# Altium schematic sheet 07_V5_LED.SchDoc(07_V5_LED)
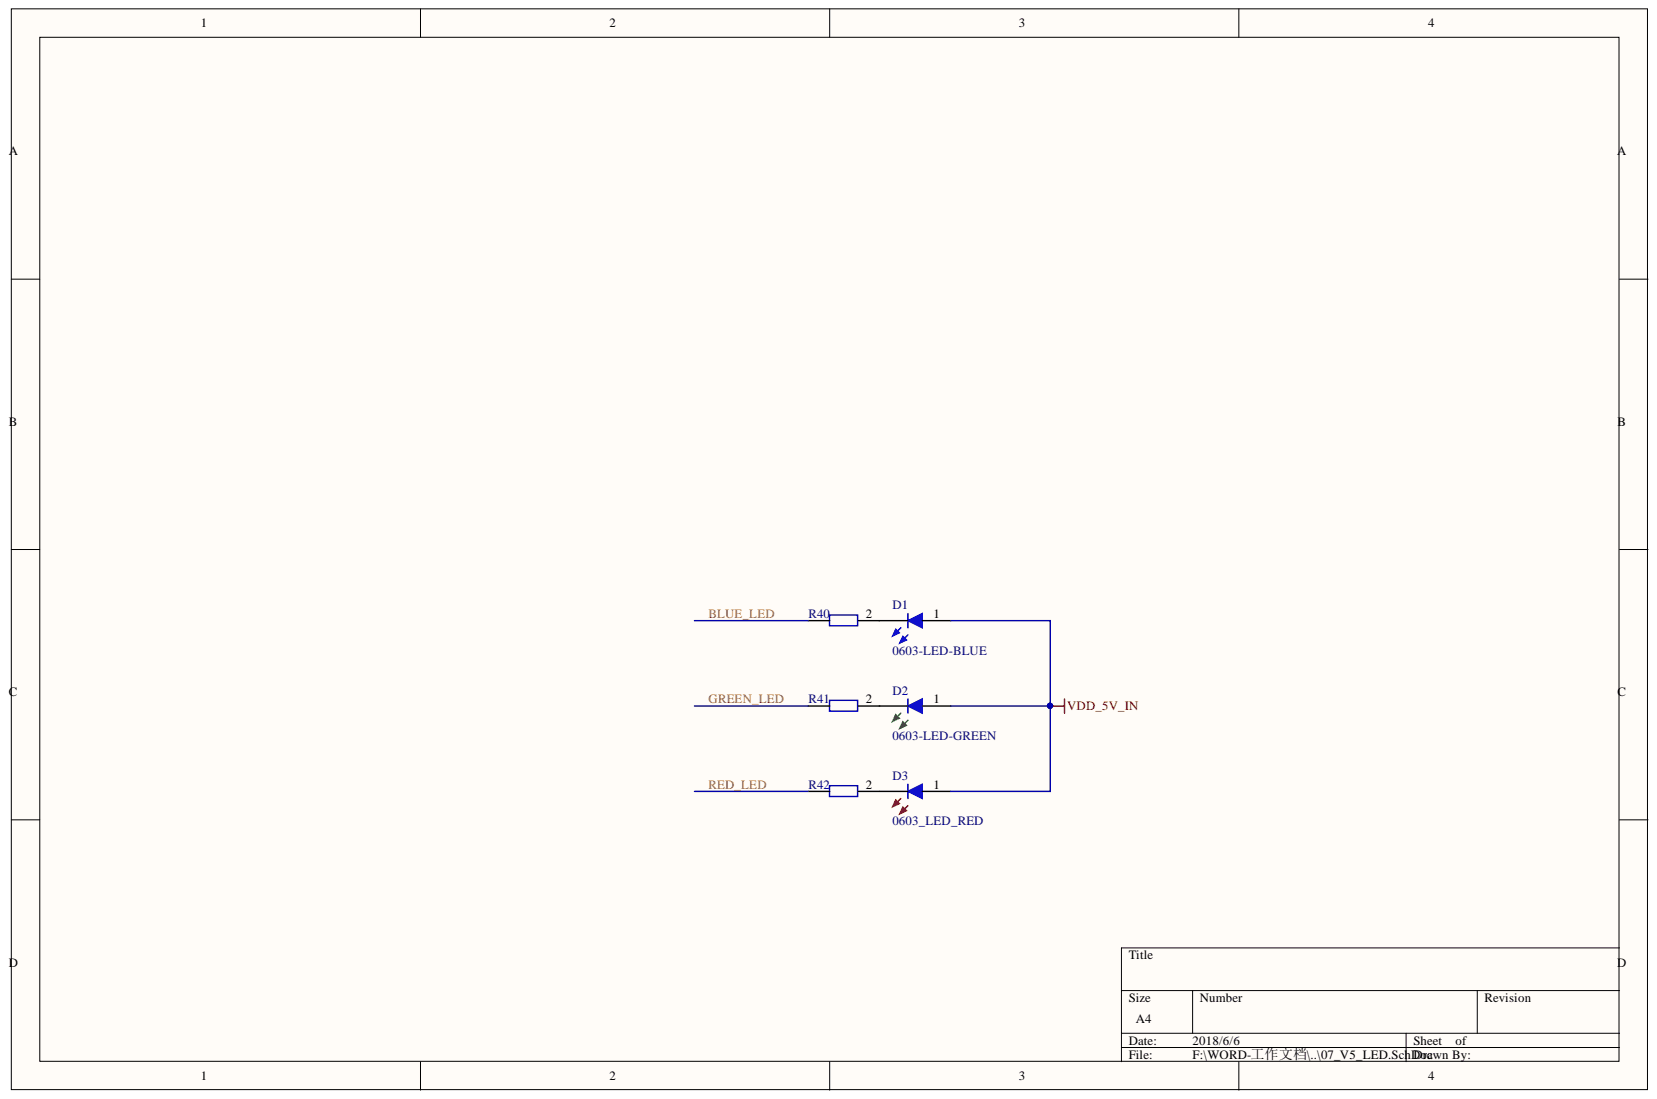
[diagram p0: 07_V5_LED.SchDoc]

## Parts
D1  0603-LED-BLUE  [0603-LED-blue]
D2  0603-LED-GREEN  [0603-LED-Green]
D3  0603_LED_RED  [0603-LED-RED]
R40  1K  [0402R]  (value not drawn)
R41  1K  [0402R]  (value not drawn)
R42  1K  [0402R]  (value not drawn)

## Nets
VDD_5V_IN: D1.1 D2.1 D3.1
BLUE_LED: R40.1
GREEN_LED: R41.1
RED_LED: R42.1
NetD1_2: D1.2 R40.2
NetD2_2: D2.2 R41.2
NetD3_2: D3.2 R42.2

## Library data of the parts
R40-R42 = 0402R100R: 0402R100R 1%; Component Kind: Standard; Library Name: CUAV.SchLib
D1 = 0603-LED-BLUE: Component Kind: Standard; Description: 0603-LED-BLUE; Library Name: CUAV.SchLib
D2 = 0603-LED-GREEN: Component Kind: Standard; Description: 0603-LED-GREEN; Library Name: CUAV.SchLib
D3 = 0603_LED_RED: Component Kind: Standard; Description: 0603_LED_RED; Library Name: CUAV.SchLib
